# Altium schematic sheet power.SchDoc(power)
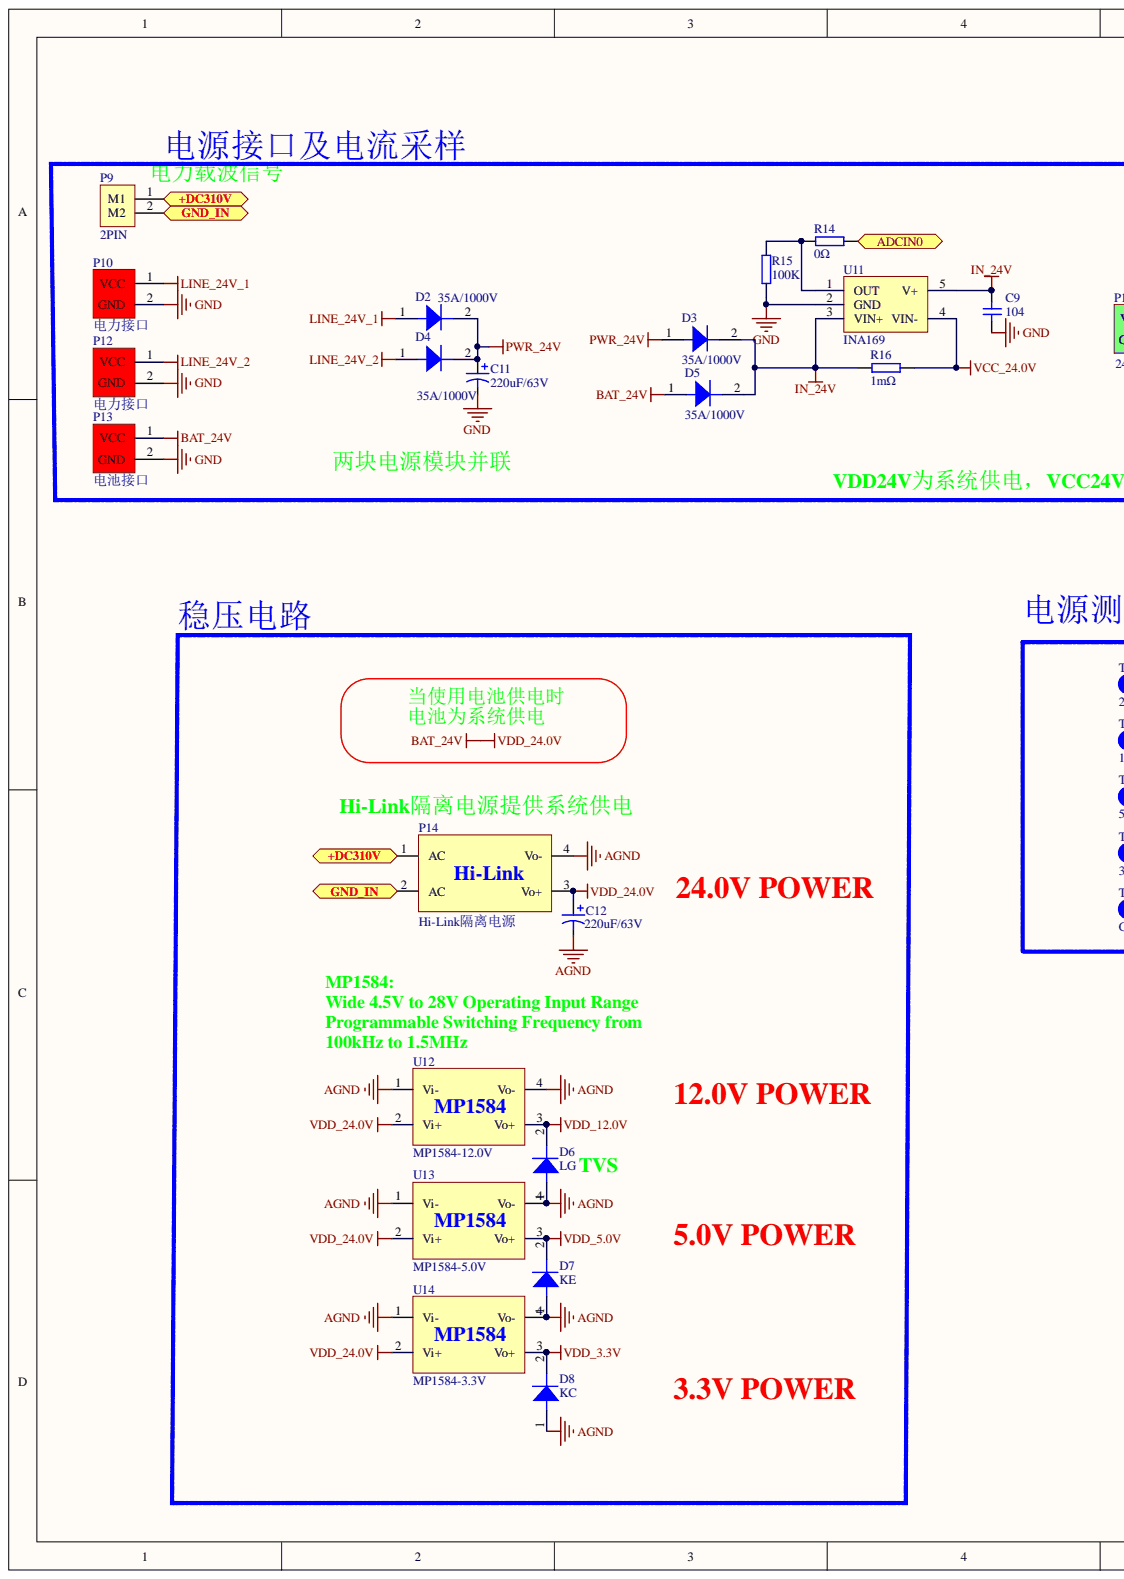
[diagram p0: power.SchDoc, left]
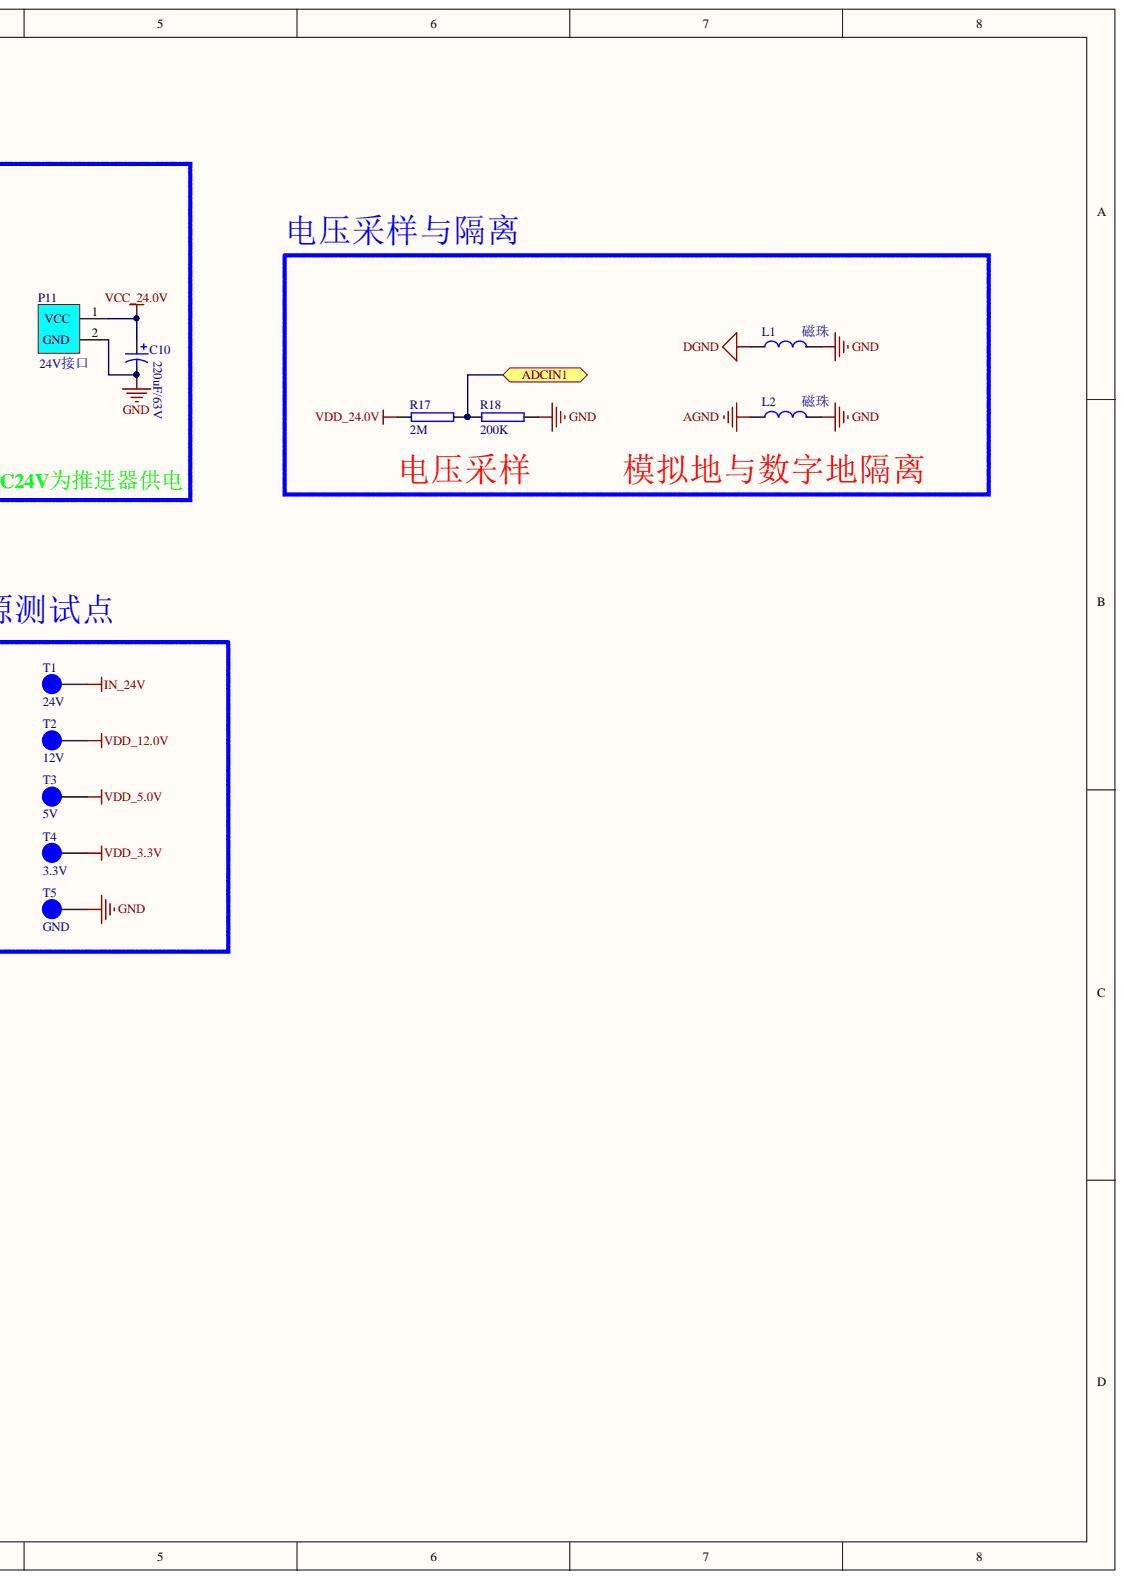
[diagram p1: power.SchDoc, right]

## Parts
C9  104  [0805C]
C10  220uF/63V  [RB.2.4]
C11  220uF/63V  [RB.2.4]
C12  220uF/63V  [RB.2.4]
D2  35A/1000V  [R-6]
D3  35A/1000V  [R-6]
D4  35A/1000V  [R-6]
D5  35A/1000V  [R-6]
D6  LG  [SMA]
D7  KE  [SMA]
D8  KC  [SMA]
L1  磁珠  [1206L]
L2  磁珠  [1206L]
P9  2PIN  [PH2.0WS-2P]
P10  电力接口  [XT60-F]
P11  24V接口  [XT60-F]
P12  电力接口  [XT60-F]
P13  电池接口  [XT60-F]
P14  Hi-Link隔离电源  [HLK-20M24]
R14  0Ω  [0805R]
R15  100K  [0805R]
R16  1mΩ  [2512R]
R17  2M  [0805R]
R18  200K  [0805R]
T1  24V  [HEADER 1]
T2  12V  [HEADER 1]
T3  5V  [HEADER 1]
T4  3.3V  [HEADER 1]
T5  GND  [HEADER 1]
U11  INA169  [SOT23-5]
U12  MP1584-12.0V  [MP1584电源模块]
U13  MP1584-5.0V  [MP1584电源模块]
U14  MP1584-3.3V  [MP1584电源模块]

## Ports
+DC310V, ADCIN0, ADCIN1, GND_IN

## Nets
AGND: C12.2 D6.1 D7.1 D8.1 L2.1 P14.4(Vo-) U12.1(Vi-) U12.4(Vo-) U13.1(Vi-) U13.4(Vo-) U14.1(Vi-) U14.4(Vo-)
BAT_24V: C12.1 D5.1 P13.1 P14.3(Vo+) R17.1 U12.2(Vi+) U13.2(Vi+) U14.2(Vi+)
DGND: L1.1
GND: C9.1 C10.2 C11.2 L1.2 L2.2 P10.2 P11.2 P12.2 P13.2 R15.1 R18.2 T5.1 U11.2(GND)
IN_24V: C9.2 D3.2 D5.2 R16.2 T1.1 U11.3(VIN+) U11.5(V+)
LINE_24V_1: D2.1 P10.1
LINE_24V_2: D4.1 P12.1
PWR_24V: C11.1 D2.2 D3.1 D4.2
VCC_24.0V: C10.1 P11.1 R16.1 U11.4(VIN-)
VDD_3.3V: D8.2 T4.1 U14.3(Vo+)
VDD_5.0V: D7.2 T3.1 U13.3(Vo+)
VDD_12.0V: D6.2 T2.1 U12.3(Vo+)
+DC310V: P9.1 P14.1(AC) ; port +DC310V
ADCIN0: R14.1 ; port ADCIN0
ADCIN1: R17.2 R18.1 ; port ADCIN1
GND_IN: P9.2 P14.2(AC) ; port GND_IN
NetR14_2: R14.2 R15.2 U11.1(OUT)
N00212:
N00213:

## Library data of the parts
C9 = Cap1: 无极贴片电容; Component Kind: Standard; Library Name: 集大电协1.5.SchLib
C10-C12 = Cap3: 直插极性电容; Component Kind: Standard; Library Name: 集大电协1.5.SchLib
D2-D8 = Diode: 二极管; Component Kind: Standard; Library Name: 集大电协1.5.SchLib
L1 L2 = L: 电感; Component Kind: Standard; Library Name: 集大电协1.5.SchLib
P9 = 接线端子-2PIN: Component Kind: Standard; Description: 2PIN; Library Name: 集大电协1.5.SchLib
P10 P12 P13 = 电源端子: Component Kind: Standard; Library Name: 集大电协1.5.SchLib
P11 = 推进器电源: Component Kind: Standard; Library Name: 集大电协1.5.SchLib
P14 = HILINK-24V: Component Kind: Standard; Library Name: 集大电协1.5.SchLib
R14-R16 = Res: 电阻; Component Kind: Standard; Library Name: 集大电协1.5.SchLib
R17 R18 = R1: Component Kind: Standard; Library Name: 窝牛器件封装.SchLib
T1-T5 = 测试点: Component Kind: Standard; Description: 测试点; Library Name: 集大电协1.2.SchLib
U12-U14 = MP1584电源模块: Component Kind: Standard; Library Name: 集大电协1.5.SchLib
U11 = INA169: Component Kind: Standard; Library Name: 集大电协1.5.SchLib
